# Altium schematic sheet Connectors.SchDoc
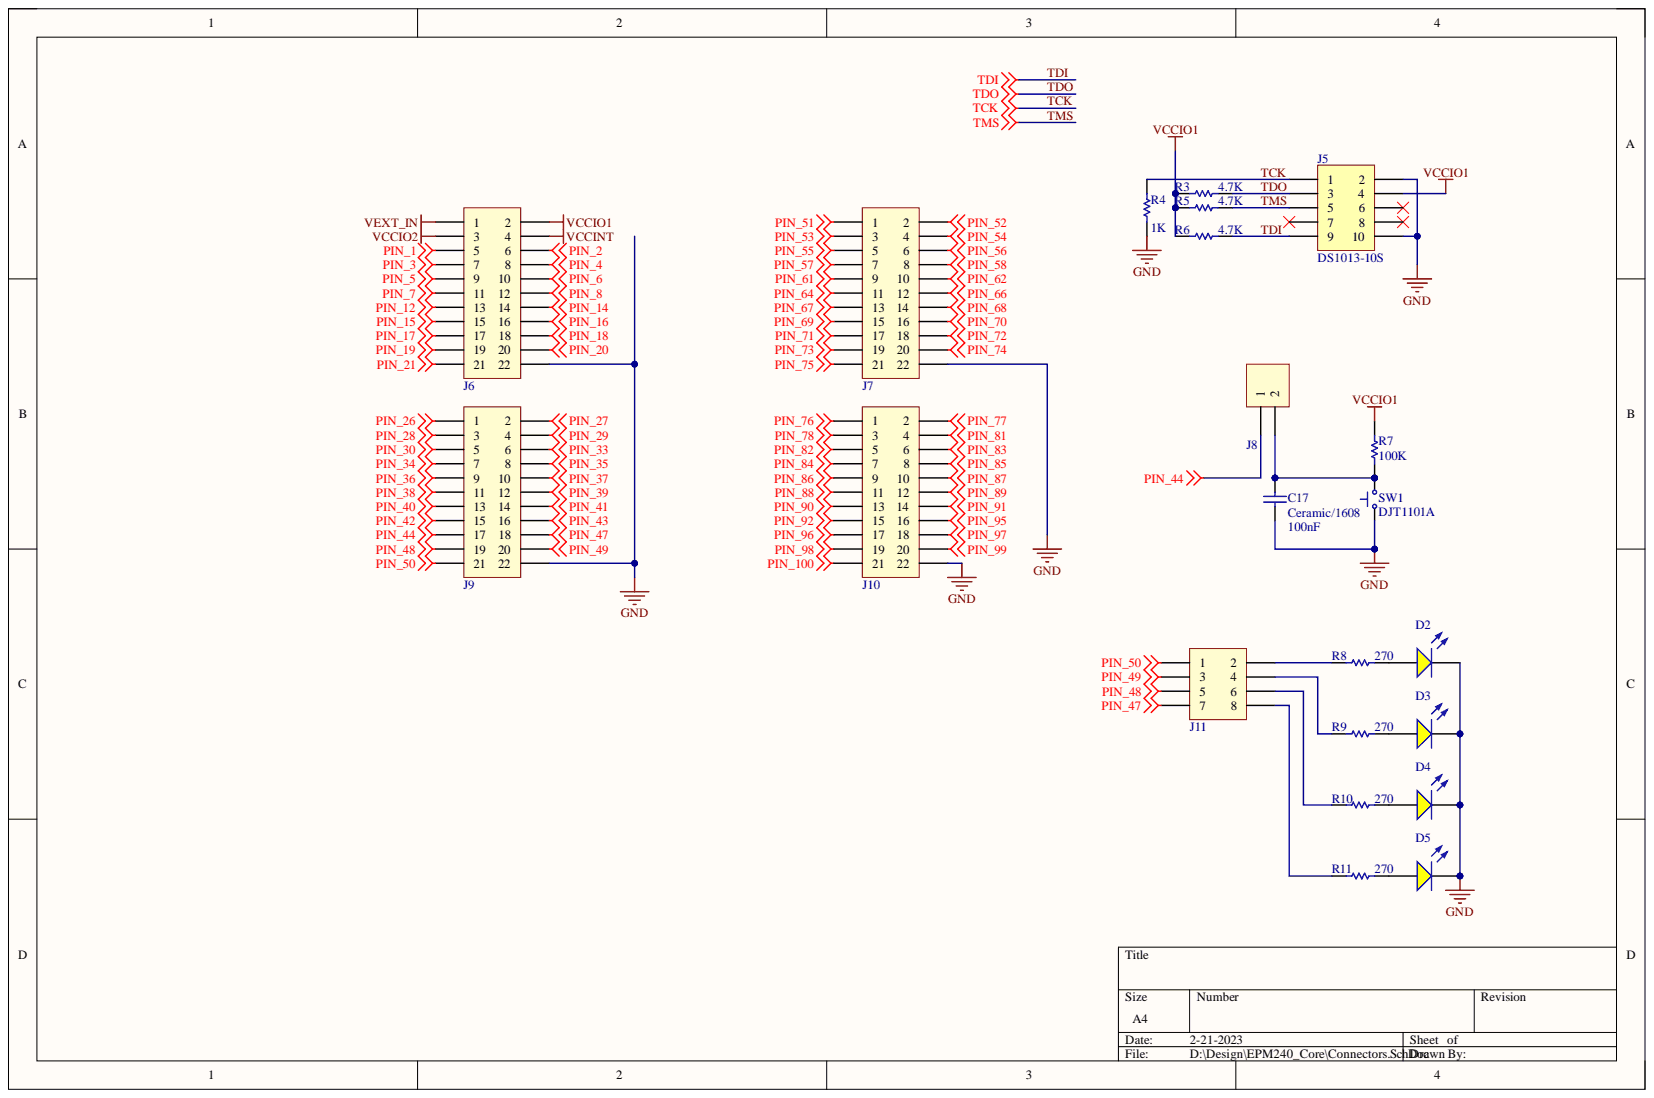
[diagram p0: Connectors.SchDoc]

## Parts
C17  Ceramic/1608  value 100nF  [Generic 1608 Ceramic]
D2  Generic 1608 LED YELLOW  [Generic 1608 RED LED-Footprint-1]  (value not drawn)
D3  Generic 1608 LED YELLOW  [Generic 1608 RED LED-Footprint-1]  (value not drawn)
D4  Generic 1608 LED YELLOW  [Generic 1608 RED LED-Footprint-1]  (value not drawn)
D5  Generic 1608 LED YELLOW  [Generic 1608 RED LED-Footprint-1]  (value not drawn)
J5  DS1013-10S  [BOX-CON_5X2]
J6  61302221121  [61302221121]  (value not drawn)
J7  61302221121  [61302221121]  (value not drawn)
J8  61300211121  [61300211121]  (value not drawn)
J9  61302221121  [61302221121]  (value not drawn)
J10  61302221121  [61302221121]  (value not drawn)
J11  61300821121  [61300821121]  (value not drawn)
R3  value 4.7K  [Generic 1608-Footprint-1]  (hidden comment: Generic 1608)
R4  value 1K  [Generic 1608-Footprint-1]  (hidden comment: Generic 1608)
R5  value 4.7K  [Generic 1608-Footprint-1]  (hidden comment: Generic 1608)
R6  value 4.7K  [Generic 1608-Footprint-1]  (hidden comment: Generic 1608)
R7  value 100K  [Generic 1608-Footprint-1]  (hidden comment: Generic 1608)
R8  value 270  [Generic 1608-Footprint-1]  (hidden comment: Generic 1608)
R9  value 270  [Generic 1608-Footprint-1]  (hidden comment: Generic 1608)
R10  value 270  [Generic 1608-Footprint-1]  (hidden comment: Generic 1608)
R11  value 270  [Generic 1608-Footprint-1]  (hidden comment: Generic 1608)
SW1  DJT1101A  [DJT1101A-Footprint-1]

## Nets
GND: C17.1 D2.2 D3.2 D4.2 D5.2 J5.2 J5.10 J6.22 J7.22 J9.22 J10.22 R4.2 SW1.1
PIN_1: J6.5
PIN_2: J6.6
PIN_3: J6.7
PIN_4: J6.8
PIN_5: J6.9
PIN_6: J6.10
PIN_7: J6.11
PIN_8: J6.12
PIN_12: J6.13
PIN_14: J6.14
PIN_15: J6.15
PIN_16: J6.16
PIN_17: J6.17
PIN_18: J6.18
PIN_19: J6.19
PIN_20: J6.20
PIN_21: J6.21
PIN_26: J9.1
PIN_27: J9.2
PIN_28: J9.3
PIN_29: J9.4
PIN_30: J9.5
PIN_33: J9.6
PIN_34: J9.7
PIN_35: J9.8
PIN_36: J9.9
PIN_37: J9.10
PIN_38: J9.11
PIN_39: J9.12
PIN_40: J9.13
PIN_41: J9.14
PIN_42: J9.15
PIN_43: J9.16
PIN_44: J8.1 J9.17
PIN_47: J9.18 J11.7
PIN_48: J9.19 J11.5
PIN_49: J9.20 J11.3
PIN_50: J9.21 J11.1
PIN_51: J7.1
PIN_52: J7.2
PIN_53: J7.3
PIN_54: J7.4
PIN_55: J7.5
PIN_56: J7.6
PIN_57: J7.7
PIN_58: J7.8
PIN_61: J7.9
PIN_62: J7.10
PIN_64: J7.11
PIN_66: J7.12
PIN_67: J7.13
PIN_68: J7.14
PIN_69: J7.15
PIN_70: J7.16
PIN_71: J7.17
PIN_72: J7.18
PIN_73: J7.19
PIN_74: J7.20
PIN_75: J7.21
PIN_76: J10.1
PIN_77: J10.2
PIN_78: J10.3
PIN_81: J10.4
PIN_82: J10.5
PIN_83: J10.6
PIN_84: J10.7
PIN_85: J10.8
PIN_86: J10.9
PIN_87: J10.10
PIN_88: J10.11
PIN_89: J10.12
PIN_90: J10.13
PIN_91: J10.14
PIN_92: J10.15
PIN_95: J10.16
PIN_96: J10.17
PIN_97: J10.18
PIN_98: J10.19
PIN_99: J10.20
PIN_100: J10.21
VCCINT: J6.4
VCCIO1: J5.4 J6.2 R3.1 R5.1 R6.1 R7.2
VCCIO2: J6.3
VEXT_IN: J6.1
TCK: J5.1 R4.1
TDI: J5.9 R6.2
TDO: J5.3 R3.2
TMS: J5.5 R5.2
NetC17_2: C17.2 J8.2 R7.1 SW1.2
NetD2_1: D2.1 R8.2
NetD3_1: D3.1 R9.2
NetD4_1: D4.1 R10.2
NetD5_1: D5.1 R11.2
NetJ11_2: J11.2 R8.1
NetJ11_4: J11.4 R9.1
NetJ11_6: J11.6 R10.1
NetJ11_8: J11.8 R11.1

Not connected: J5 6 7 8

## Library data of the parts
C17 = CMP-002-0009-3: Generic 1608 Ceramic Capacitor; Capacitor Type: Ceramic; Case/Package: 1608; Component Kind: Standard; Library Name: C6H6`s Vault; Mount: SMD; Pins: 2; RoHS: Yes
D2-D5 = CMP-008-0004-2: Generic 1608 LED <YELLOW>; Component Kind: Standard; Library Name: C6H6`s Vault
J5 = CMP-001-0020-3: 2.54mm Box Header 5x2; Component Kind: Standard; Component Name: DS1013-10S; Designator: DS1013-10S; Library Name: C6H6`s Vault; Pin Count: 10
J6 J7 J9 J10 = CMP-005-0101-1: Pin Header WR-PHD, pitch 2.54 mm, THT, Vertical, dual row, 22 pin; Contact Resistance: 20 mOhm; Insulation Resistance: >1000 MOhm; Pin Number: 22; Pitch: 2.54 mm; Rated Current: 3 A; Working Voltage: 250 VAC; Component Kind: Standard; Component Name: 61302221121; ComponentLink1Description: Manufacturer; ComponentLink1URL: http://www.we-online.de; ComponentLink2Description: Datasheet; ComponentLink2URL: http://katalog.we-online.de/em/datasheet/6130xx21121.pdf; Designator: 61302221121; Library Name: C6H6`s Vault; Mounting Technology: THT; Operating Temperature: -40 to 105 degC; Orientation: Vertical; Part Number: 61302221121; Pin Count: 22; Published Date: Jan. 2018; Row Number: Dual; Publisher: Wurth Elektronik
J8 = CMP-005-0052-1: Pin Header WR-PHD, pitch 2.54 mm, THT, Vertical, single row, 2 pin; Contact Resistance: 20 mOhm; Insulation Resistance: >1000 MOhm; Pin Number: 2; Pitch: 2.54 mm; Rated Current: 3 A; Working Voltage: 250 VAC; Component Kind: Standard; Component Name: 61300211121; ComponentLink1Description: Manufacturer; ComponentLink1URL: http://www.we-online.de; ComponentLink2Description: Datasheet; ComponentLink2URL: http://katalog.we-online.de/em/datasheet/6130xx11121.pdf; Designator: 61300211121; Library Name: C6H6`s Vault; Mounting Technology: THT; Operating Temperature: -40 to 105 degC; Orientation: Vertical; Part Number: 61300211121; Pin Count: 2; Published Date: Jan. 2018; Row Number: Single; Publisher: Wurth Elektronik
J11 = CMP-005-0070-1: Pin Header WR-PHD, pitch 2.54 mm, THT, Vertical, dual row, 8 pin; Contact Resistance: 20 mOhm; Insulation Resistance: >1000 MOhm; Pin Number: 8; Pitch: 2.54 mm; Rated Current: 3 A; Working Voltage: 250 VAC; Component Kind: Standard; Component Name: 61300821121; ComponentLink1Description: Manufacturer; ComponentLink1URL: http://www.we-online.de; ComponentLink2Description: Datasheet; ComponentLink2URL: http://katalog.we-online.de/em/datasheet/6130xx21121.pdf; Designator: 61300821121; Library Name: C6H6`s Vault; Mounting Technology: THT; Operating Temperature: -40 to 105 degC; Orientation: Vertical; Part Number: 61300821121; Pin Count: 8; Published Date: Jan. 2018; Row Number: Dual; Publisher: Wurth Elektronik
R3-R11 = CMP-001-0018-1: Generic 1608 Resistor; Case/Package: Bulk; Component Kind: Standard; Library Name: C6H6`s Vault; Mount: SMD; Pins: 2; RoHS: Yes
SW1 = CMP-013-0000-2: TACT Switch, 2P, 5mm, White; Case/Package: Bulk; Component Kind: Standard; Library Name: C6H6`s Vault; Mount: Thruhole; Pins: 2; RoHS: Yes
